# Altium schematic sheet Power_Supply.SchDoc
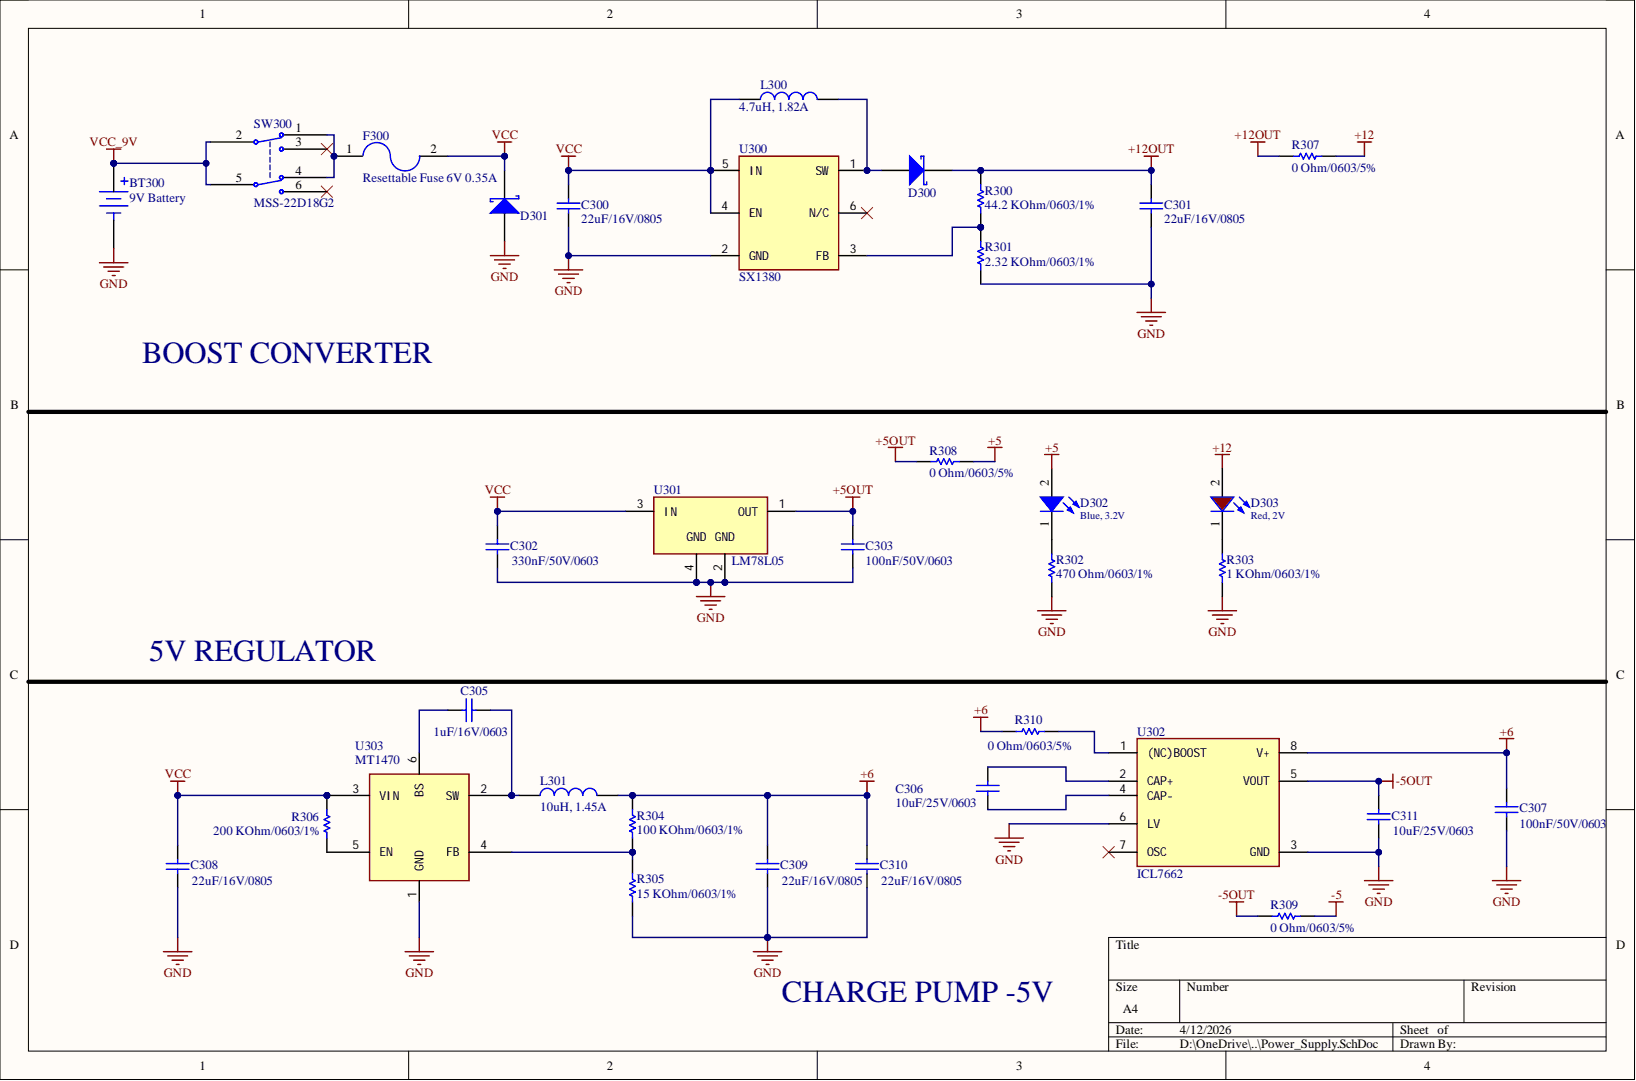
[diagram p0: Power_Supply.SchDoc]

## Parts
BT300  value 9V Battery  [BAT_BC9VPC]  (hidden comment: BC9VPC)
C300  value 22uF/16V/0805  [CAP-SM-0805]  (hidden comment: Cap Non-Pol SMD)
C301  value 22uF/16V/0805  [CAP-SM-0805]  (hidden comment: Cap Non-Pol SMD)
C302  330nF, 50V  value 330nF/50V/0603  [WCAP-CSGP_0603_H=0.8mm_Reflow]
C303  value 100nF/50V/0603  [WCAP-CSGP_0603_H=0.8mm_Reflow]  (hidden comment: 100nF, 25V)
C305  1uF, 16V  value 1uF/16V/0603  [WCAP-CSGP_0603_H=0.8mm_Reflow]
C306  value 10uF/25V/0603  [WCAP-CSGP_0603_H=0.8mm_Reflow]  (hidden comment: 10uF, 6.3V)
C307  value 100nF/50V/0603  [WCAP-CSGP_0603_H=0.8mm_Reflow]  (hidden comment: 100nF, 25V)
C308  value 22uF/16V/0805  [WCAP-CSGP_0805_H=1.25mm]  (hidden comment: 22uF, 10V)
C309  value 22uF/16V/0805  [WCAP-CSGP_0805_H=1.25mm]  (hidden comment: 22uF, 10V)
C310  value 22uF/16V/0805  [WCAP-CSGP_0805_H=1.25mm]  (hidden comment: 22uF, 10V)
C311  value 10uF/25V/0603  [WCAP-CSGP_0603_H=0.8mm_Reflow]  (hidden comment: 10uF, 6.3V)
D300  D Schottky  [SS34]  (value not drawn)
D301  D Schottky  [SS34]  (value not drawn)
D302  Blue, 3.2V  Forward Voltage typ.: 3.2V  [WL-SMCW_0603_H=0.7mm]
D303  Red, 2V  Forward Voltage typ.: 2V  [WL-SMCW_0603_H=0.7mm]
F300  value Resettable Fuse 6V 0.35A  [FUSE1206]  (hidden comment: RESETTABLE FUSE 1206)
L300  4.7uH, 1.82A  Rated Current: 1.82A  [WE-PD2A_4532]
L301  10uH, 1.45A  Rated Current: 1.45A  [WE-PD2A_4532]
R300  value 44.2 KOhm/0603/1%  [R0603]  (hidden comment: Resistor 44.2 KOhm 0603 1%)
R301  value 2.32 KOhm/0603/1%  [R0603]  (hidden comment: Resistor 2.32 KOhm 0603 1%)
R302  value 470 Ohm/0603/1%  [R0603]  (hidden comment: Resistor 470 Ohm 0603 1%)
R303  value 1 KOhm/0603/1%  [R0603]  (hidden comment: Resistor 1 KOhm 0603 1%)
R304  value 100 KOhm/0603/1%  [R0603]  (hidden comment: Resistor 100 KOhm 0603 1%)
R305  value 15 KOhm/0603/1%  [R0603]  (hidden comment: Resistor 15 KOhm 0603 1%)
R306  value 200 KOhm/0603/1%  [R0603]  (hidden comment: Resistor 200 KOhm 0603 5%)
R307  value 0 Ohm/0603/5%  [R0603]  (hidden comment: Resistor 0 Ohm 0603 5%)
R308  value 0 Ohm/0603/5%  [R0603]  (hidden comment: Resistor 0 Ohm 0603 5%)
R309  value 0 Ohm/0603/5%  [R0603]  (hidden comment: Resistor 0 Ohm 0603 5%)
R310  value 0 Ohm/0603/5%  [R0603]  (hidden comment: Resistor 0 Ohm 0603 5%)
SW300  value MSS-22D18G2  [MSS-22D18G2]  (hidden comment: Slide Switch)
U300  value SX1380  [SOT-23-6]  (hidden comment: SX1308)
U301  78L05  value LM78L05  [SOT-89]
U302  value ICL7662  [SOIC-8]  (hidden comment: ICL7662EBA+)
U303  MT1470  [SOT-23-6]

## Nets
+5: D302.2 R308.2
+5OUT: C303.1 R308.1 U301.1(OUT)
+6: C307.1 C309.2 C310.2 L301.2 R304.2 R310.1 U302.8(V+)
+12: D303.2 R307.2
+12OUT: C301.2 D300.2 R300.2 R307.1
-5: R309.2
-5OUT: C311.2 R309.1 U302.5(VOUT)
GND: BT300.N C300.1 C301.1 C302.1 C303.2 C307.2 C308.1 C309.1 C310.1 C311.1 D301.1 R301.1 R302.1 R303.1 R305.1 U300.2(GND) U301.2 U301.4 U302.3(GND) U302.6(LV) U303.1(GND)
VCC: C300.2 C302.2 C308.2 D301.2 F300.2 L300.1 R306.2 U300.4(EN) U300.5(IN) U301.3(IN) U303.3(VIN)
VCC_9V: BT300.P SW300.2 SW300.5
NetC305_1: C305.1 U303.6(BS)
NetC305_2: C305.2 L301.1 U303.2(SW)
NetC306_1: C306.1 U302.4(CAP-)
NetC306_2: C306.2 U302.2(CAP+)
NetD300_1: D300.1 L300.2 U300.1(SW)
NetD302_1: D302.1 R302.2
NetD303_1: D303.1 R303.2
NetF300_1: F300.1 SW300.1 SW300.4
NetR300_1: R300.1 R301.2 U300.3(FB)
NetR304_1: R304.1 R305.2 U303.4(FB)
NetR306_1: R306.1 U303.5(EN)
NetR310_2: R310.2 U302.1((NC)BOOST)

Not connected: SW300 3 6; U300 6(N/C); U302 7(OSC)

## Library data of the parts
BT300 = BC9VPC: Battery Holder 1 Cells 9V Size Plastic Tray; MF: MPD (Memory Protection Devices); Check_prices: https://www.snapeda.com/parts/BC9VPC/Memory+Protection+Devices/view-part/?ref=eda; Component Kind: Standard; MP: BC9VPC; Package: None; SnapEDA_Link: https://www.snapeda.com/parts/BC9VPC/Memory+Protection+Devices/view-part/?ref=snap; Library Name: Giang's Libs.IntLib
C300 C301 = Cap Non-Pol SMD: Capacitor; Component Kind: Standard; Library Name: PIF_Library.IntLib
C302 = 885012206121: WCAP-CSGP Multilayer Ceramic Chip Capacitor, General Purpose, size 0603, X7R, 330nF, 50V; Manufacturer: Wurth Elektronik; Capacitance Tolerance: +/-10%; Dissipation Factor: #NAME?; Isolation Resistance: >=1.5GOhm; Rated Voltage: 50VDC; Technical Reference: X7R0603334K050DFCT10000; Ceramic Type: X7R; Component Kind: Standard; ComponentLink1Description: Manufacturer; ComponentLink1URL: http://www.we-online.de; ComponentLink2Description: Datasheet; ComponentLink2URL: https://www.we-online.com/catalog/datasheet/885012206121.pdf; Height: 0.8mm; Library Name: Altium_WCAP-CSGP (rev20a).IntLib; Manufacturer Part Number: 885012206121; Mounting Technology: SMT; Operating Temperature: -55 to +125degC; Packaging: Tape and Reel; Published Date: May 2020; Size: 0603
C303 C307 = 885012206071: WCAP-CSGP Multilayer Ceramic Chip Capacitor, General Purpose, size 0603, X7R, 100nF, 25V; Manufacturer: Wurth Elektronik; Capacitance Tolerance: +/-10%; Dissipation Factor: #NAME?; Isolation Resistance: >=5GOhm; Rated Voltage: 25VDC; Technical Reference: X7R0603104K025DFCT10000; Ceramic Type: X7R; Component Kind: Standard; ComponentLink1Description: Manufacturer; ComponentLink1URL: http://www.we-online.de; ComponentLink2Description: Datasheet; ComponentLink2URL: https://www.we-online.com/catalog/datasheet/885012206071.pdf; Height: 0.8mm; Library Name: Altium_WCAP-CSGP (rev20a).IntLib; Manufacturer Part Number: 885012206071; Mounting Technology: SMT; Operating Temperature: -55 to +125degC; Packaging: Tape and Reel; Published Date: May 2020; Size: 0603
C305 = 885012106017: WCAP-CSGP Multilayer Ceramic Chip Capacitor, General Purpose, size 0603, X5R, 1uF, 16V; Manufacturer: Wurth Elektronik; Capacitance Tolerance: +/-20%; Dissipation Factor: #NAME?; Isolation Resistance: >=0.1GOhm; Rated Voltage: 16VDC; Technical Reference: X5R0603105M016DFCT10000; Ceramic Type: X5R; Component Kind: Standard; ComponentLink1Description: Manufacturer; ComponentLink1URL: http://www.we-online.de; ComponentLink2Description: Datasheet; ComponentLink2URL: https://www.we-online.com/catalog/datasheet/885012106017.pdf; Height: 0.8mm; Library Name: Altium_WCAP-CSGP (rev20a).IntLib; Manufacturer Part Number: 885012106017; Mounting Technology: SMT; Operating Temperature: -55 to +85degC; Packaging: Tape and Reel; Published Date: May 2020; Size: 0603
C306 C311 = 885012106006: WCAP-CSGP Multilayer Ceramic Chip Capacitor, General Purpose, size 0603, X5R, 10uF, 6.3V; Manufacturer: Wurth Elektronik; Capacitance Tolerance: +/-20%; Dissipation Factor: #NAME?; Isolation Resistance: >=0.01GOhm; Rated Voltage: 6.3VDC; Technical Reference: X5R0603106M6R3DFCT10000; Ceramic Type: X5R; Component Kind: Standard; ComponentLink1Description: Manufacturer; ComponentLink1URL: http://www.we-online.de; ComponentLink2Description: Datasheet; ComponentLink2URL: https://www.we-online.com/catalog/datasheet/885012106006.pdf; Height: 0.8mm; Library Name: Altium_WCAP-CSGP (rev20a).IntLib; Manufacturer Part Number: 885012106006; Mounting Technology: SMT; Operating Temperature: -55 to +85degC; Packaging: Tape and Reel; Published Date: May 2020; Size: 0603
C308-C310 = 885012107011: WCAP-CSGP Multilayer Ceramic Chip Capacitor, General Purpose, size 0805, X5R, 22uF, 10V; Manufacturer: Wurth Elektronik; Capacitance Tolerance: +/-20%; Dissipation Factor: #NAME?; Isolation Resistance: >=0.005GOhm; Rated Voltage: 10VDC; Technical Reference: X5R0805226M010DFCT10000; Ceramic Type: X5R; Component Kind: Standard; ComponentLink1Description: Manufacturer; ComponentLink1URL: http://www.we-online.de; ComponentLink2Description: Datasheet; ComponentLink2URL: https://www.we-online.com/catalog/datasheet/885012107011.pdf; Height: 1.25mm; Library Name: Altium_WCAP-CSGP (rev20a).IntLib; Manufacturer Part Number: 885012107011; Mounting Technology: SMT; Operating Temperature: -55 to +85degC; Packaging: Tape and Reel; Published Date: May 2020; Size: 0805
D300 D301 = D Schottky: Schottky Diode; Code_JEDEC: DO-214-AA; Component Kind: Standard; LatestRevisionDate: 17-Jul-2002; LatestRevisionNote: Re-released for DXP Platform.; Library Name: PIF_Library.IntLib; PackageDescription: DO-214-AA/SMB; 2 C-Bend Leads; Body 5.3 x 3.6 mm, inc. leads (LxW); PackageReference: SMB; Published: 8-Jun-2000; Publisher: Altium Limited
D302 = 150060BS75000: WL-SMCW SMT Mono-color Chip LED Waterclear, size 0603, Blue, 3.2V, 140deg; Chip Technology: InGaN; Dominant Wavelength typ.: 470nm; Luminous Intensity typ.: 145mcd; Peak Wavelength typ.: 465nm; Component Kind: Standard; ComponentLink1Description: Manufacturer; ComponentLink1URL: http://www.we-online.de; ComponentLink2Description: Datasheet; ComponentLink2URL: https://www.we-online.com/catalog/datasheet/150060BS75000.pdf; Emitting Color: Blue; Library Name: Altium_WL-SMCW (rev20a).IntLib; Mounting Technology: SMT; Operating Temperature: -40 to +85degC; Packaging: Tape and Reel; Part Number: 150060BS75000; Published Date: December 2019; Size: 0603; Viewing Angle: 140deg; Publisher: Wurth Elektronik
D303 = 150060RS75000: WL-SMCW SMT Mono-color Chip LED Waterclear, size 0603, Red, 2V, 140deg; Chip Technology: AlInGaP; Dominant Wavelength typ.: 625nm; Luminous Intensity typ.: 250mcd; Peak Wavelength typ.: 630nm; Component Kind: Standard; ComponentLink1Description: Manufacturer; ComponentLink1URL: http://www.we-online.de; ComponentLink2Description: Datasheet; ComponentLink2URL: https://www.we-online.com/catalog/datasheet/150060RS75000.pdf; Emitting Color: Red; Library Name: Altium_WL-SMCW (rev20a).IntLib; Mounting Technology: SMT; Operating Temperature: -40 to +85degC; Packaging: Tape and Reel; Part Number: 150060RS75000; Published Date: December 2019; Size: 0603; Viewing Angle: 140deg; Publisher: Wurth Elektronik
F300 = RESETTABLE FUSE 1206: Polymeric PTC Resettable Fuse 6V 350mA Ih Surface Mount 1206 _3216 Metric_, Concave; MF: Littelfuse Inc.; MP: 1206L035YR; Value: Resettable Fuse 6V 0.35A; Availability: Unavailable; Component Kind: Standard; Package: 1206 Littelfuse; Price: None; SnapEDA_Link: https://www.snapeda.com/parts/1206L035YR/Littelfuse%20Inc./view-part/225191/?ref=snap; Library Name: Giang's Libs.IntLib
L300 = 784773047: WE-PD2A SMT Power Inductor, size 4532, 4.7uH, 1.82A; Manufacturer: Wurth Elektronik; DC Resistance max.: 0.11Ohm; DC Resistance typ.: 0.059Ohm; Rated Voltage: 80VDC; Saturation Current: 2.46A; Self Resonant Frequency: 45MHz; Component Kind: Standard; ComponentLink1Description: Manufacturer; ComponentLink1URL: http://www.we-online.de; ComponentLink2Description: Datasheet; ComponentLink2URL: https://www.we-online.com/catalog/datasheet/784773047.pdf; Library Name: Altium_WE-PD2A (rev20a).IntLib; Manufacturer Part Number: 784773047; Mounting Technology: SMT; Operating Temperature: -40 to +125degC; Packaging: Tape and Reel; Published Date: May 2020; Size: 4532; Value: 4.7uH, 1.82A
L301 = 78477310: WE-PD2A SMT Power Inductor, size 4532, 10uH, 1.45A; Manufacturer: Wurth Elektronik; DC Resistance max.: 0.182Ohm; DC Resistance typ.: 0.118Ohm; Rated Voltage: 80VDC; Saturation Current: 1.74A; Self Resonant Frequency: 30MHz; Component Kind: Standard; ComponentLink1Description: Manufacturer; ComponentLink1URL: http://www.we-online.de; ComponentLink2Description: Datasheet; ComponentLink2URL: https://www.we-online.com/catalog/datasheet/78477310.pdf; Inductance: 10uH; Library Name: Altium_WE-PD2A (rev20a).IntLib; Manufacturer Part Number: 78477310; Mounting Technology: SMT; Operating Temperature: -40 to +125degC; Packaging: Tape and Reel; Published Date: May 2020; Size: 4532
R300 = Resistor 44.2 KOhm 0603 1%: Code (4422, 63C), 1/10W 1%; Component Kind: Standard; ComponentLink1Description: Buy; ComponentLink1URL: https://www.thegioiic.com/dien-tro-44-2-kohm-0603-1-; Library Name: Giang_Resistor.IntLib
R301 = Resistor 2.32 KOhm 0603 1%: Code (2321, 36B), 1/10W; Component Kind: Standard; ComponentLink1Description: Buy; ComponentLink1URL: https://www.thegioiic.com/dien-tro-2-7-kohm-0603-5-; Library Name: Giang_Resistor.IntLib
R302 = Resistor 470 Ohm 0603 1%: Code 471, 1/10W; Component Kind: Standard; ComponentLink1Description: Buy; ComponentLink1URL: https://www.thegioiic.com/dien-tro-470-ohm-0603-1-; Library Name: Giang_Resistor.IntLib
R303 = Resistor 1 KOhm 0603 1%: Code 102, 1/10W; Component Kind: Standard; ComponentLink1Description: Buy; ComponentLink1URL: https://www.thegioiic.com/dien-tro-1-kohm-0603-1; Library Name: Giang_Resistor.IntLib
R304 = Resistor 100 KOhm 0603 1%: Code 104, 1/10W; Component Kind: Standard; ComponentLink1Description: Buy; ComponentLink1URL: https://www.thegioiic.com/dien-tro-100-kohm-0603-1-; Library Name: Giang_Resistor.IntLib
R305 = Resistor 15 KOhm 0603 1%: Code 153, 1/10W; Component Kind: Standard; ComponentLink1Description: Buy; ComponentLink1URL: https://www.thegioiic.com/dien-tro-15-kohm-0603-1-; Library Name: Giang_Resistor.IntLib
R306 = Resistor 200 KOhm 0603 5%: Code 2003, 1/10W; Value: 200 KOhm/0603/1%; Component Kind: Standard; ComponentLink1Description: Buy; ComponentLink1URL: https://www.thegioiic.com/dien-tro-200-kohm-0603-1-; Library Name: Giang_Resistor.IntLib
R307-R310 = Resistor 0 Ohm 0603 5%: Code 0R, 1/10W; Component Kind: Standard; ComponentLink1Description: Buy; ComponentLink1URL: https://www.thegioiic.com/dien-tro-0-ohm-0603-5-; Library Name: Giang_Resistor.IntLib
U300 = SX1308: High Efficiency 1.2MHz 2A Step Up Converter; MF: Semtech Corporation; Availability: In Stock; Check_prices: https://www.snapeda.com/parts/SX1308/Semtech+Corporation/view-part/?ref=eda; Component Kind: Standard; MP: SX1308; Package: - Semtech Corporation; Price: None; Purchase-URL: https://pricing.snapeda.com/search/part/SX1308/?ref=eda; SnapEDA_Link: https://www.snapeda.com/parts/SX1308/Semtech+Corporation/view-part/?ref=snap; Library Name: Giang's Libs.IntLib
U301 = 78L05: IC REG LINEAR 5V 100MA SOT89-3; MF: Hottech Co; Availability: Not in stock; Check_prices: https://www.snapeda.com/parts/78L05/Hottech+Semiconductor/view-part/?ref=eda; Component Kind: Standard; MP: 78L05; Package: None; Price: None; SnapEDA_Link: https://www.snapeda.com/parts/78L05/Hottech+Semiconductor/view-part/?ref=snap; Library Name: Giang's Libs.IntLib
U302 = ICL7662EBA+: CMOS, Voltage Converters; MF: Analog Devices; Availability: In Stock; Check_prices: https://www.snapeda.com/parts/ICL7662EBA+/Analog+Devices/view-part/?ref=eda; Component Kind: Standard; ComponentLink1Description: Buy; ComponentLink1URL: https://www.thegioiic.com/icl7662cba; MP: ICL7662EBA+; Package: SOIC-8 Maxim; Price: None; Purchase-URL: https://pricing.snapeda.com/search/part/ICL7662EBA+/?ref=eda; SnapEDA_Link: https://www.snapeda.com/parts/ICL7662EBA+/Analog+Devices/view-part/?ref=snap; Library Name: Giang's Libs.IntLib
U303 = MT1470: 2A, 4.5 - 18V Input, 500kHz Synchronous Step-Down Converter; Component Kind: Standard; ComponentLink1Description: Buy; ComponentLink1URL: https://www.thegioiic.com/mt1470-ic-dieu-chinh-giam-ap-2a-sot-23-6; Value: MT1470; Library Name: Giang's Libs.IntLib
SW300 = Slide Switch: Component Kind: Standard; ComponentLink1Description: Buy; ComponentLink1URL: https://www.thegioiic.com/mss-22d18g2-cong-tac-truot-6-chan-smd-2p2t; Description: Slide Switch; Library Name: Giang's Libs.IntLib
